# Altium schematic sheet BeamBreakFix.SchDoc
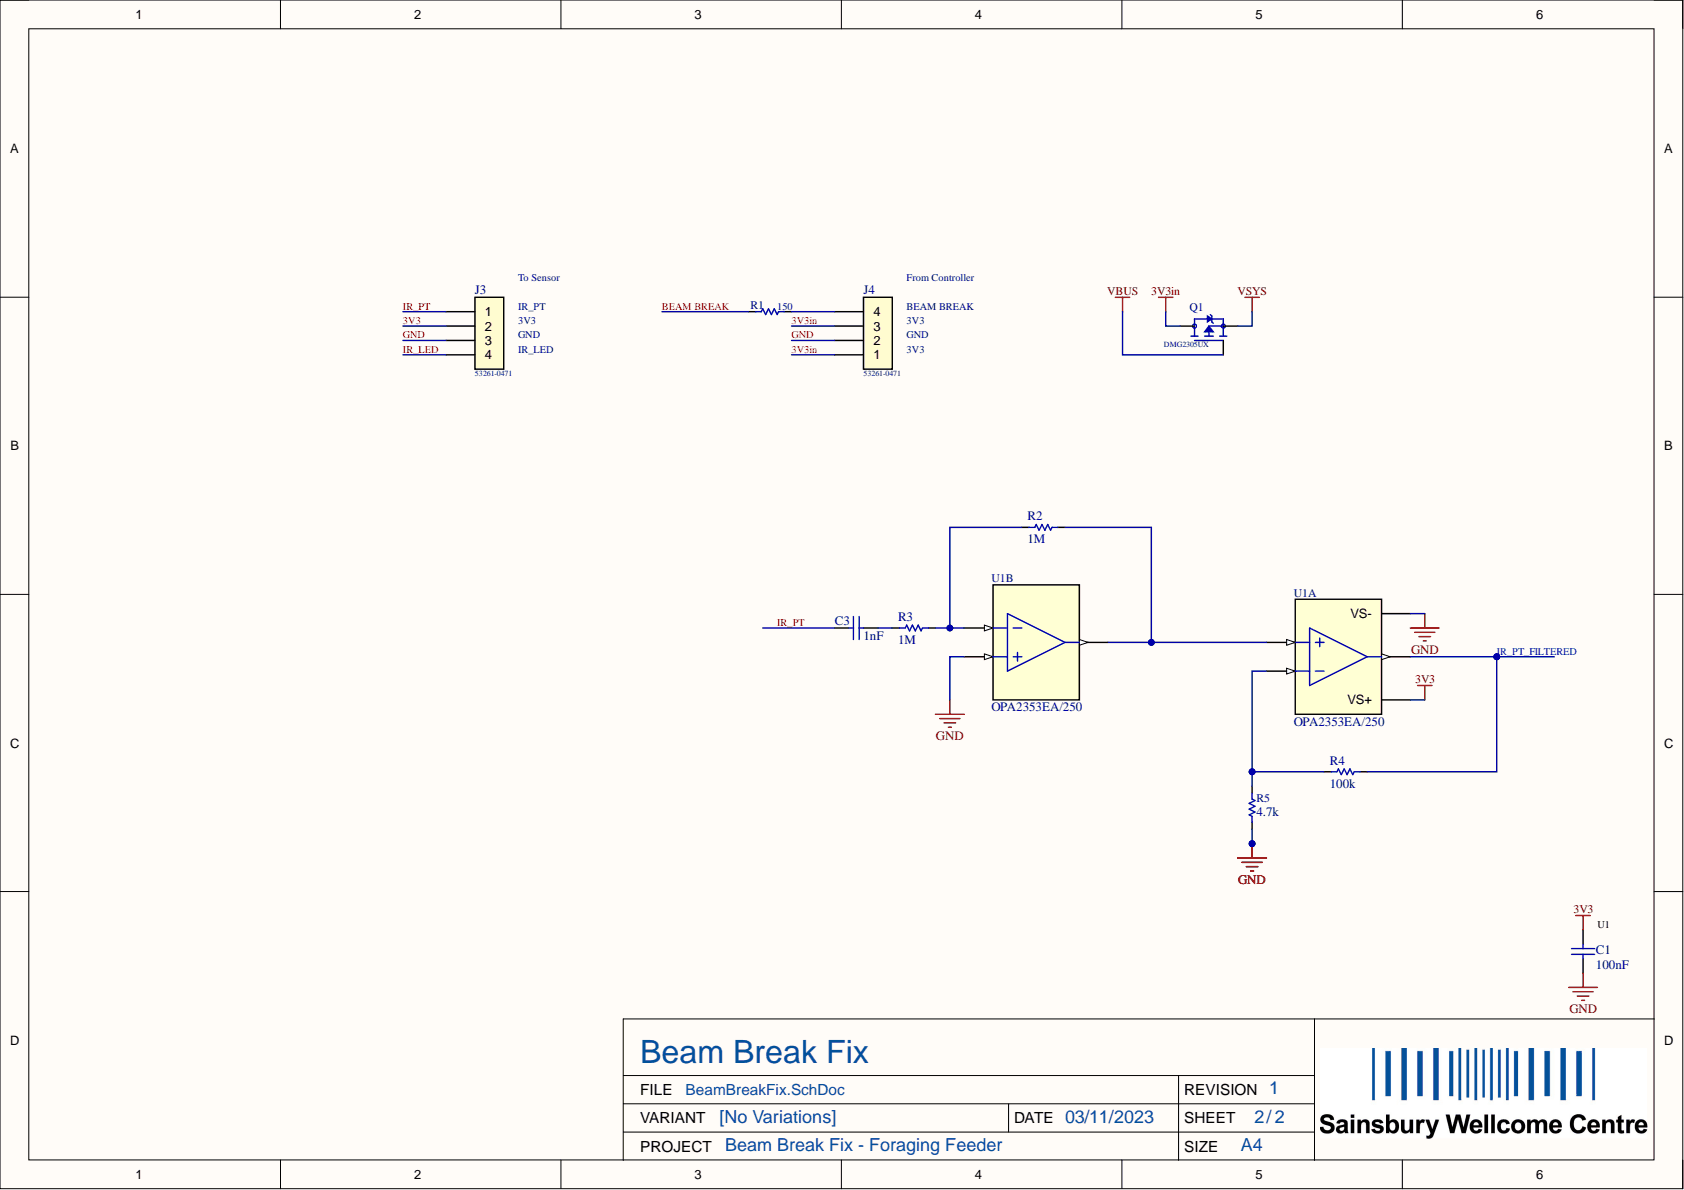
[diagram p0: BeamBreakFix.SchDoc]

## Parts
C1  value 100nF  Capacitance: 100nF  [FP-GRM188-0_1-e0_2_0_5-IPC_B]  (hidden comment: C0603-100nF-100V-10%-X7R)
C3  value 1nF  [FP-0603-L_1_6_0_15-W_0_81-IPC_C]  (hidden comment: C0603-1nF-50V-1%-C0G)
J3  53261-0471  [MOLEX_53261-0471]
J4  53261-0471  [MOLEX_53261-0471]
Q1  PartNumber: DMG2305UX  [FP-SOT23-IPC_B]  (hidden comment: DMG2305UX-7)
R1  value 150  [FP-CPF0603-IPC_B]  (hidden comment: R0603-150R-1/16W-0.1%)
R2  value 1M  Resistance: 1M  [FP-CPF0603-IPC_B]  (hidden comment: R0603-1M-1/16W-0.1%)
R3  value 1M  Resistance: 1M  [FP-CPF0603-IPC_B]  (hidden comment: R0603-1M-1/16W-0.1%)
R4  value 100k  Resistance: 100k  [FP-CPF0603-IPC_B]  (hidden comment: R0603-100k-1/16W-0.1%)
R5  value 4.7k  Resistance: 4.7k  [FP-CPF0603-IPC_B]  (hidden comment: R0603-4k7-1/16W-0.1%)
U1  OPA2353EA/250  [DGK0008A_N]  (parts A,B)

## Nets
VBUS: Q1.1
VSYS: Q1.2
3V3: C1.2 J3.2 U1.8(VS+)
3V3in: J4.1 J4.3 Q1.3
BEAM BREAK: R1.1
GND: C1.1 J3.3 J4.2 R5.1 U1.4(VS-) U1.5
IR_LED: J3.4
IR_PT: C3.1 J3.1
IR_PT_FILTERED: R4.2 U1.1
NetC3_2: C3.2 R3.1
NetJ4_4: J4.4 R1.2
NetR2_1: R2.1 R3.2 U1.6
NetR2_2: R2.2 U1.3 U1.7
NetR4_1: R4.1 R5.2 U1.2

## Library data of the parts
C1 = CMP-611-0027-19: 0.1µF ±10% 100V Ceramic Capacitor X7R 0603 (1608 Metric); Pick&PlaceFootprint: 0603_CAP; Capacitor Type: Ceramic; Case Code (Imperial): 0603; Case Code (Metric): 1608; Case/Package: 0603; Component Kind: Standard; Construction: Flat; Depth: 0.8mm; Dielectric: X7R; Height: 0.8mm; Lead Free: Lead Free; Length: 1.6mm; Material: Ceramic; Max Operating Temperature: 125°C; Min Operating Temperature: -55°C; Mount: Surface Mount; Package Quantity: 1; Packaging: Tape and Reel; Radiation Hardening: No; REACH SVHC: No SVHC; RoHS: Yes; RoHS Compliant: Yes; Series: GRM; Size: 0.8mm; Termination: SMD/SMT; Thickness: 0.035inch; Tolerance: 10%; Voltage: 100V; Voltage Rating: 100V; Voltage Rating (DC): 100V; Weight: 0.000222oz; Width: 0.8mm; Library Name: Sainsbury Wellcome Centre
C3 = CMP-611-0035-5: 0.001µF ±1% 50V Ceramic Capacitor C0G 0603 (1608 Metric); PartNumber: C0603-1nF-50V-5%-COG; Pick&PlaceFootprint: C0603; Capacitor Type: Ceramic; Case/Package: 0603_CAP; Component Kind: Standard; Dielectric: C0G; Mount: Surface Mount; RoHS: Yes; Tolerance: 1%; Voltage Rating: 50V; Library Name: Sainsbury Wellcome Centre
J3 J4 = CMP-370-0017-6: MOLEX 1.25mm (.049") Pitch PicoBlade Header, Surface Mount, Right Angle, Lead-free, 4 Circuits; Contact Resistance: 20mR; Current Rating: 1A; Gender: Male; Glow Wire Compliant: Non-compliant; Insulation Resistance: 100MR; Number of Circuits: 4; Voltage Rating: 125V; Case/Package: 53261-0471; Color: Beige; Component Kind: Standard; Contact Gender: Male; Contact Material: Tin; Contact Plating: Tin; Contact Type: -; Flammability Rating: UL94 V-0; Housing Color: Natural; Housing Material: Thermoplastic; Lead Free: Lead Free; Lead Pitch: 1.25mm; Max Operating Temperature: 105°C; Min Operating Temperature: -40°C; Mount: Surface Mount; Number of Contacts: 4; Number of Positions: 4; Number of Rows: 1; Orientation: Right Angle; Package Quantity: 1000; Packaging: Cut Tape; Pitch: 1.25mm; Radiation Hardening: No; REACH SVHC: Unknown; Series: 53261; Termination: SMD/SMT; Voltage Rating (AC): 125V; Voltage Rating (DC): 125V; Weight: 0.005598oz; Working Voltage: 125V; Library Name: Sainsbury Wellcome Centre
Q1 = CMP-830-0007-1: Power Field-Effect Transistor, 4.2A I(D), 20V, 0.052ohm, 1-Element, P-Channel, Silicon, Metal-oxide Semiconductor FET; Pick&PlaceFootprint: SOT-23-3; Component Kind: Standard; Mount: Surface Mount; Library Name: Sainsbury Wellcome Centre
R1 = CMP-633-0078-2: Surface Mount Resistor 150 Ohm 1/16 W 0.1% 0603 (1608 Metric); Max Power Dissipation: 62.5 mW; Number of Resistors: 1; Pick&PlaceFootprint: R0603; Power Rating: 62.5mW; Temperature Coefficient: 25ppm/°C; Voltage Rating: 50V; Case Code (Imperial): 0603; Case Code (Metric): 1608; Case/Package: 0603; Component Kind: Standard; Composition: Thin Film; Construction: Chip; ELV: Compliant; Height: 0.45mm; Length: 1.6mm; Max Operating Temperature: 155°C; Min Operating Temperature: -55°C; Mount: Surface Mount; Number of Pins: 2; Number of Terminations: 2; Packaging: Tape and Reel; REACH SVHC: Yes; Resistance: 150; Resistance Tolerance: 0.1%; Resistor Type: FIXED RESISTOR; RoHS: Yes; RoHS Compliant: Yes; Series: CPF; Size Code: 0603; Technology: THIN FILM; Termination: Wraparound; Tolerance: 0.1%; Voltage Rating (DC): 50V; Weight: 7.1E-05oz; Width: 0.8mm; Library Name: Sainsbury Wellcome Centre
R2 R3 = CMP-633-0066-9: Surface Mount Resistor 1M Ohm 1/16 W 0.1% 0603 (1608 Metric); Max Power Dissipation: 62.5 mW; Number of Resistors: 1; Pick&PlaceFootprint: R0603; Power Rating: 62.5mW; Temperature Coefficient: 25ppm/°C; Voltage Rating: 50V; Case Code (Imperial): 0603; Case Code (Metric): 1608; Case/Package: 0603; Component Kind: Standard; Composition: Thin Film; ELV: Compliant; Height: 0.45mm; Length: 1.6mm; Max Operating Temperature: 155°C; Min Operating Temperature: -55°C; Mount: Surface Mount; Number of Pins: 2; Number of Terminations: 2; Packaging: Tape and Reel; REACH SVHC: No SVHC; Resistance Tolerance: 0.1%; Resistor Type: Thin Film; RoHS: Yes; RoHS Compliant: Yes; Series: CPF; Size Code: 0603; Technology: Thin Film; Termination: Solder; Tolerance: 0.1%; Voltage Rating (DC): 50V; Weight: 7.1E-05oz; Width: 0.8mm; Library Name: Sainsbury Wellcome Centre
R4 = CMP-633-0000-17: Surface Mount Resistor 100k Ohm 1/16 W 0.1% 0603 (1608 Metric); Max Power Dissipation: 62.5 mW; Number of Resistors: 1; Pick&PlaceFootprint: R0603; Power Rating: 62.5mW; Temperature Coefficient: 25ppm/°C; Voltage Rating: 50V; Case Code (Imperial): 0603; Case Code (Metric): 1608; Case/Package: 0603; Component Kind: Standard; Composition: Thin Film; ELV: Compliant; Height: 0.45mm; Length: 1.6mm; Max Operating Temperature: 155°C; Min Operating Temperature: -55°C; Mount: Surface Mount; Number of Pins: 2; Number of Terminations: 2; Packaging: Tape and Reel; REACH SVHC: No SVHC; Resistance Tolerance: 0.1%; Resistor Type: Thin Film; RoHS: Yes; RoHS Compliant: Yes; Series: CPF; Size Code: 0603; Technology: Thin Film; Termination: Solder; Tolerance: 0.1%; Voltage Rating (DC): 50V; Weight: 7.1E-05oz; Width: 0.8mm; Library Name: Sainsbury Wellcome Centre
R5 = CMP-633-0024-17: Surface Mount Resistor 4.7k Ohm 1/16 W 0.1% 0603 (1608 Metric); Max Power Dissipation: 62.5 mW; Number of Resistors: 1; Pick&PlaceFootprint: R0603; Power Rating: 62.5mW; Temperature Coefficient: 25ppm/°C; Voltage Rating: 50V; Case Code (Imperial): 0603; Case Code (Metric): 1608; Case/Package: 0603; Component Kind: Standard; Composition: Thin Film; ELV: Compliant; Height: 0.45mm; Length: 1.6mm; Max Operating Temperature: 155°C; Min Operating Temperature: -55°C; Mount: Surface Mount; Number of Pins: 2; Number of Terminations: 2; Packaging: Tape and Reel; REACH SVHC: No SVHC; Resistance Tolerance: 0.1%; Resistor Type: Thin Film; RoHS: Yes; RoHS Compliant: Yes; Series: CPF; Size Code: 0603; Technology: Thin Film; Termination: Solder; Tolerance: 0.1%; Voltage Rating (DC): 50V; Weight: 7.1E-05oz; Width: 0.8mm; Library Name: Sainsbury Wellcome Centre
U1 = CMP-941-0006-5: Operational Amplifier, 2 Func, 8000uV Offset-Max, CMOS, PDSO8; Package Equivalence Code: TSSOP8,.19; Pick&PlaceFootprint: TSSOP8; Component Kind: Standard; PartNumber: OPA2353EA/250; Library Name: Sainsbury Wellcome Centre
